# Altium schematic sheet LT220_LD V1.0 .SchDoc
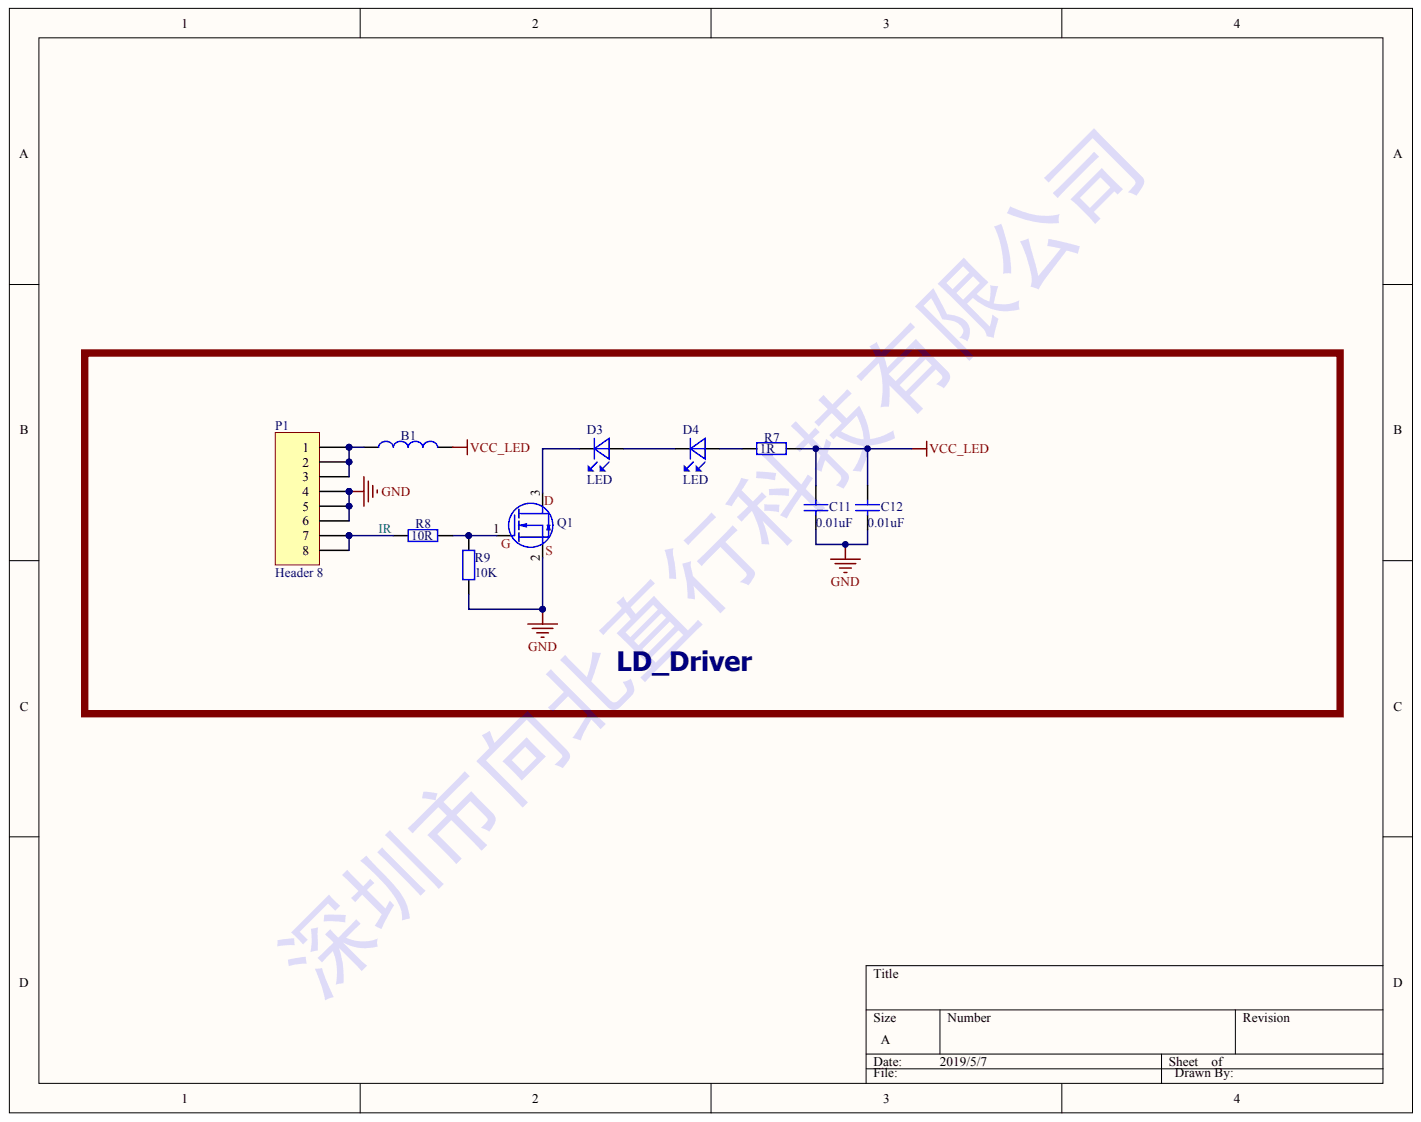
[diagram p0: LT220_LD V1.0 .SchDoc]

## Parts
B1  (no value)
C11  value 0.01uF  (hidden comment: Cap)
C12  value 0.01uF  (hidden comment: Cap)
D3  LED
D4  LED
P1  Header 8
Q1  (no value)
R7  value 1R  (hidden comment: Res2)
R8  value 10R  (hidden comment: Res2)
R9  value 10K  (hidden comment: Res2)

## Nets
GND: C11.1 C12.1 P1.4 P1.5 P1.6 Q1.2 R9.1
VCC_LED: B1.2 C11.2 C12.2 R7.2
IR: P1.7 P1.8 R8.1
NetB1_1: B1.1 P1.1 P1.2 P1.3
NetD3_1: D3.1 D4.2
NetD3_2: D3.2 Q1.3
NetD4_1: D4.1 R7.1
NetQ1_1: Q1.1 R8.2 R9.2

## Library data of the parts
B1 C11 C12 D3 D4 P1 Q1 R7-R9 = (no symbol name)
  C11 C12: Published: 8-Jun-2000; LatestRevisionDate: 17-Jul-2002; LatestRevisionNote: Re-released for DXP Platform.; PackageReference: RAD-0.3; PackageDescription: Radial Cap, Thru-Hole; 2 Leads; 0.3 in Pin Spacing
  P1: LatestRevisionDate: 17-Jul-2002; LatestRevisionNote: Re-released for DXP Platform.
  R7-R9: Published: 8-Jun-2000; LatestRevisionDate: 17-Jul-2002; LatestRevisionNote: Re-released for DXP Platform.; PackageReference: AXIAL-0.4; PackageDescription: Axial Device, Thru-Hole; 2 Leads; 0.4 in Pin Spacing
  C11 C12 P1 R7-R9: Publisher: Altium Limited
